# Altium schematic sheet MCU.SchDoc
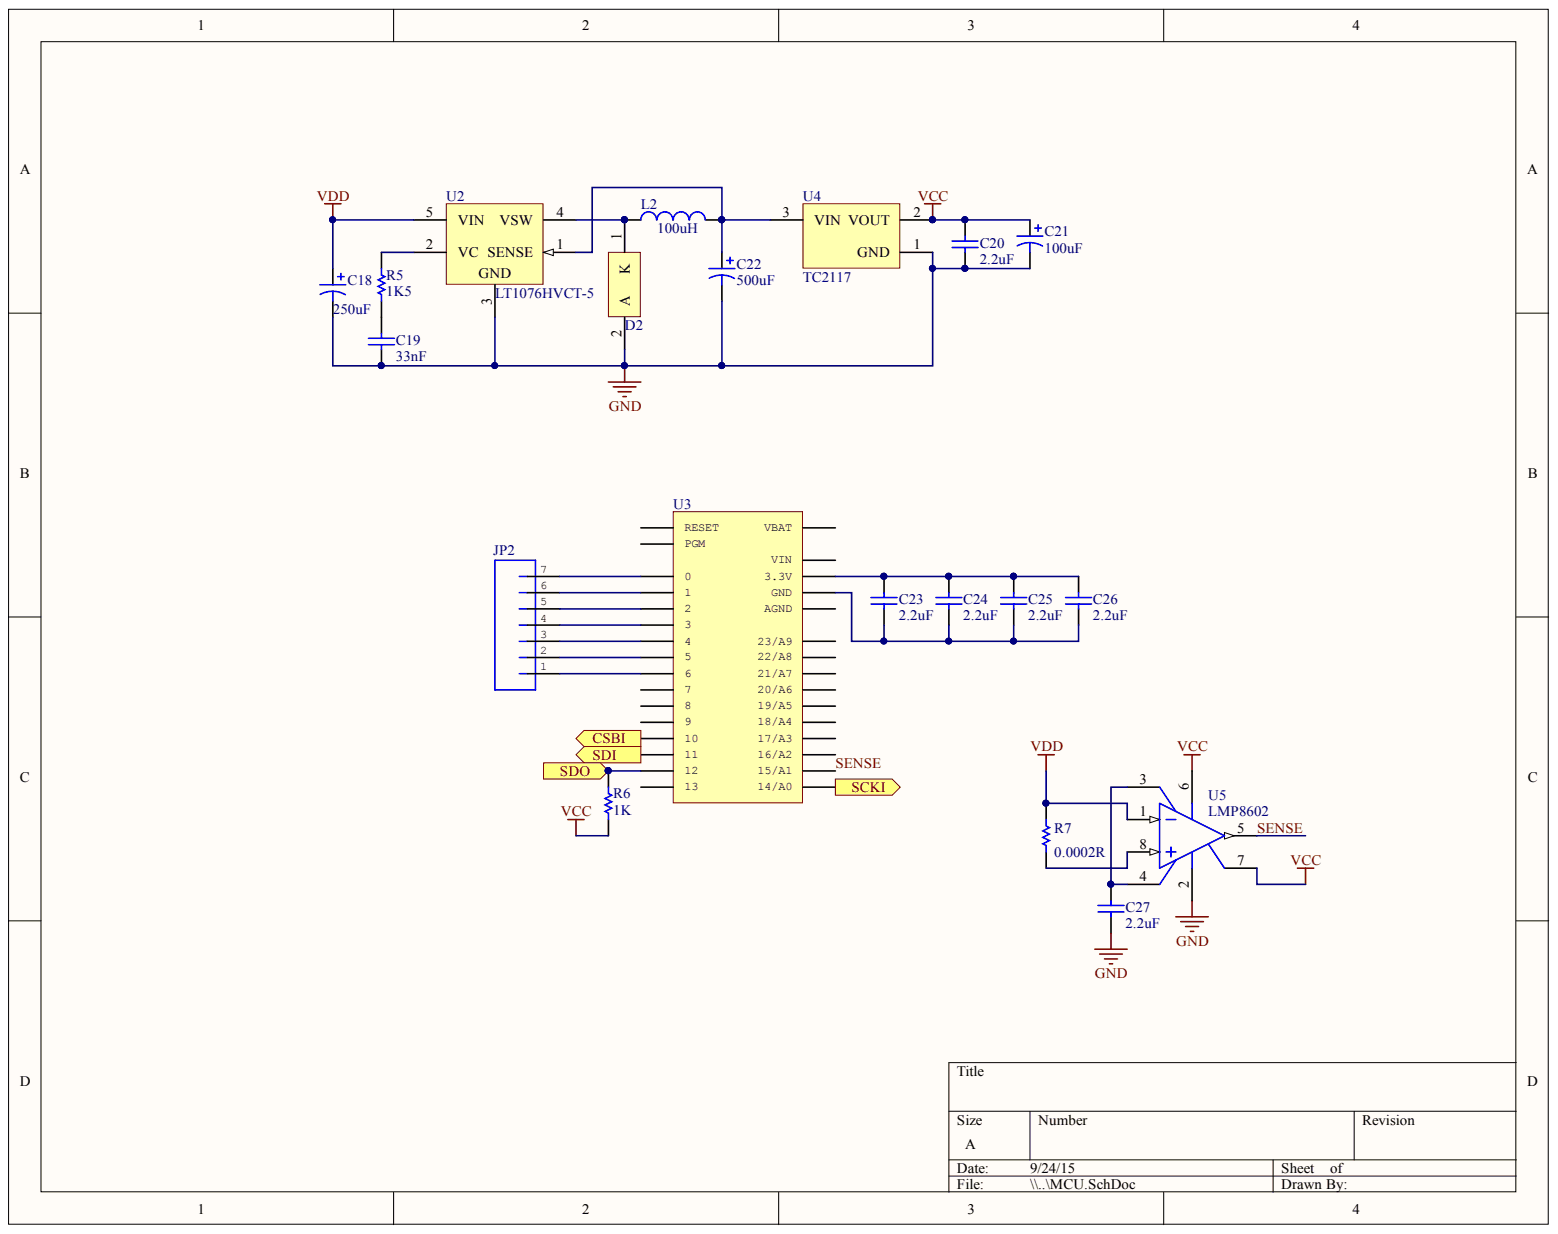
[diagram p0: MCU.SchDoc]

## Parts
C18  250uF  [250uF 100V]
C19  33nF  [CAPC0603(1608)100_M]
C20  2.2uF  [CAPC0603(1608)55_N]
C21  100uF  [AVX-CAPC7343_V]
C22  500uF  [500uF 25V]
C23  2.2uF  [CAPC0603(1608)55_N]
C24  2.2uF  [CAPC0603(1608)55_N]
C25  2.2uF  [CAPC0603(1608)55_N]
C26  2.2uF  [CAPC0603(1608)55_N]
C27  2.2uF  [CAPC0603(1608)55_N]
D2  (no value)  [ONSC-AXIAL_LEAD-2-267-05_V]
JP2  (no value)  [JST-7-PTH]
L2  value 100uH  [Inductor]
R5  1K5  [RESC0603(1608)_M]
R6  1K  [RESC0603(1608)_L]
R7  value 0.0002R  [WSLP5931]
U2  LT1076HVCT-5  [LT-T-5-S]
U3  (no value)  [TEENSY_3.0_BASIC]
U4  TC2117  [SOT-223-DB3_M]
U5  LMP8602  [M08A_L]

## Ports
CSBI, SCKI, SDI, SDO

## Nets
GND: C18.2 C19.1 C20.2 C21.2 C22.2 C27.1 D2.2 U2.3 U4.1 U5.2
VCC: C20.1 C21.1 R6.1 U4.2 U5.6 U5.7
VDD: C18.1 R7.2 U2.5(VIN) U5.1
CSBI: U3.10 ; port CSBI
SCKI: U3.14/A0 ; port SCKI
SDI: U3.11 ; port SDI
SDO: R6.2 U3.12 ; port SDO
SENSE: U3.15/A1 U5.5
NetC19_2: C19.2 R5.1
NetC22_1: C22.1 L2.2 U2.1(SENSE) U4.3
NetC23_1: C23.1 C24.1 C25.1 C26.1 U3.GND GND1(GND)
NetC23_2: C23.2 C24.2 C25.2 C26.2 U3.3.3V 3.3V1(3.3V)
NetC27_2: C27.2 U5.3 U5.4
NetD2_1: D2.1 L2.1 U2.4(VSW)
NetJP2_1: JP2.1 U3.6
NetJP2_2: JP2.2 U3.5
NetJP2_3: JP2.3 U3.4
NetJP2_4: JP2.4 U3.3
NetJP2_5: JP2.5 U3.2
NetJP2_6: JP2.6 U3.1
NetJP2_7: JP2.7 U3.0
NetR5_2: R5.2 U2.2(VC)
NetR7_1: R7.1 U5.8

Not connected: U3 7 8 9 13 16/A2 17/A3 18/A4 19/A5 20/A6 21/A7 22/A8 23/A9 AGND PGM RESET VBAT VIN

## Library data of the parts
C18 = CAP 250uF 100V: Polarized Capacitor (Axial); Component Kind: Standard; LatestRevisionDate: 17-Jul-2002; LatestRevisionNote: Re-released for DXP Platform.; Library Name: Components.SchLib; PackageDescription: Polarized Capacitor; 2 Leads; PackageReference: POLAR0.8; Published: 8-Jun-2000; Signal Integrity: Cap Pol; Simulation: CAP; Publisher: Altium Limited
C19 = CMP-1035-02452-1: CAP 33nF 6.3V Â±5% 0603 (1608 Metric) Thickness 1mm SMD; Case-EIA: 0603; Case-Metric: 1608; Component Kind: Standard; Library Name: Altium Content Vault; Max Thickness: 1 mm; Rated Voltage: 6.3 V; Technology: SMT; Tolerance: Â±5%; Value: 33nF
C20 C23-C27 = CMP-1035-02762-1: CAP 2.2uF 16V Â±10% 0603 (1608 Metric) Thickness 0.55mm SMD; Case-EIA: 0603; Case-Metric: 1608; Component Kind: Standard; Library Name: Altium Content Vault; Max Thickness: 0.55 mm; Rated Voltage: 16 V; Technology: SMT; Tolerance: Â±10%; Value: 2.2uF
C21 = CMP-0279-00002-1: Capacitor, Tantalum, 220uF 10% 10V MCCT-D (7343-31); Manufacturer: AVX; PartNumber: TAJD227K010R; Polarity: Polarized; Capacitance: 220uF; Component Kind: Standard; ComponentLink1Description: Manufacturer URL; ComponentLink1URL: http://www.avx.com/; ComponentLink2Description: Datasheet; ComponentLink2URL: http://www.avx.com/docs/Catalogs/taj.pdf; DatasheetVersion: Jul-13; Library Name: Altium Content Vault; Mounting Technology: Surface Mount; PackageDescription: 2-Pin SMT Capacitor, 7.3 x 4.3 mm Body; PackageReference: CAPC7343; Rated Voltage: 10V; Technology: Tantalum; Tolerance: 10%
C22 = CAP 500uF 25V: Polarized Capacitor (Axial); Component Kind: Standard; LatestRevisionDate: 17-Jul-2002; LatestRevisionNote: Re-released for DXP Platform.; Library Name: Components.SchLib; PackageDescription: Polarized Capacitor; 2 Leads; PackageReference: POLAR0.8; Published: 8-Jun-2000; Signal Integrity: Cap Pol; Simulation: CAP; Publisher: Altium Limited
D2 = CMP-1055-00021-1: Rectifier, 2-Pin Axial_Lead, Pb-Free, Bulk Bag; Manufacturer: On Semiconductor; PartNumber: MBR340G; Configuration: Single; IFSM Max (A): 80; Component Kind: Standard; ComponentLink1Description: Manufacturer URL; ComponentLink1URL: http://www.onsemi.com/; ComponentLink2Description: Datasheet; ComponentLink2URL: http://www.onsemi.cn/pub_link/Collateral/MBR340-D.PDF; ComponentLink3Description: Package Specification; ComponentLink3URL: http://www.onsemi.com/pub_link/Collateral/267-05.PDF; DatasheetVersion: Rev. 7; Library Name: Altium Content Vault; Mounting Technology: Through Hole; PackageDescription: 2-Pin, Axial Lead, Diameter 5.05 mm; PackageReference: AXIAL_LEAD-2-267-05; PackageVersion: Rev. G, 06/2000; Packing: Bulk Bag; RoHS: TRUE
JP2 = JST_7_RIGHT: Component Kind: Standard; Library Name: Components.SchLib
L2 = CTX100-1-52-R: Inductor; Code_IEC: 1005; Code_JEITA: 0402; Component Kind: Standard; LatestRevisionDate: 29-May-2009; LatestRevisionNote: IPC-7351 Footprint Added.; Library Name: Components.SchLib; PackageDescription: Chip Inductor; PackageReference: 0402-A; Published: 8-Jun-2000; Signal Integrity: Inductor; Simulation: INDUCTOR; Publisher: Altium Limited
R5 = CMP-1012-00078-1: 1K5 0.1W 5% 0603 (1608 Metric)  SMD; Case-EIA: 0603; Case-Metric: 1608; Value: 1500.00 Ohm; Component Kind: Standard; Library Name: Altium Content Vault; Power: 0.1 W; Technology: SMT; Tolerance: 5 %
R6 = CMP-1012-00074-1: 1K 0.1W 5% 0603 (1608 Metric)  SMD; Case-EIA: 0603; Case-Metric: 1608; Value: 1000.00 Ohm; Component Kind: Standard; Library Name: Altium Content Vault; Power: 0.1 W; Technology: SMT; Tolerance: 5 %
R7 = SHUNT: Resistor; Component Kind: Standard; LatestRevisionDate: 17-Jul-2002; LatestRevisionNote: Re-released for DXP Platform.; Library Name: Components.SchLib; PackageDescription: Axial Device, Thru-Hole; 2 Leads; 0.3 in Pin Spacing; PackageReference: AXIAL-0.3; Published: 8-Jun-2000; Signal Integrity: Res; Simulation: RESISTOR; Publisher: Altium Limited
U2 = CMP-0491-02013-1: Step-Down Switching Regulator, 5-pin FM (T-5), 0 to 70 degC; Manufacturer: Linear Technology; Number of Outputs: 1; Component Kind: Standard; ComponentLink1Description: Manufacturer URL; ComponentLink1URL: http://www.linear.com/; ComponentLink2Description: Datasheet; ComponentLink2URL: http://cds.linear.com/docs/en/datasheet/10765fc.pdf; ComponentLink3Description: Package Specification; ComponentLink3URL: http://cds.linear.com/docs/en/packaging/TO-220_5_05-08-1421.pdf; DatasheetVersion: Rev. C; Library Name: Altium Content Vault; Mounting Technology: Through Hole; PackageDescription: 5-Pin Plastic TO-220 (Standard), Body 10.224 x 8.89 mm, Pitch 1.7 mm; PackageReference: T-5; PackageVersion: Aug-10; PartNumber: LT1076HVCT-5; RoHS: FALSE
U3 = TEENSY_3.0_BASIC: Component Kind: Standard; Library Name: Components.SchLib
U4 = PM-LINEREG-TC2117-IL4: Component Kind: Standard; Library Name: Components.SchLib
U5 = CMP-0055-00659-1: 60V Common Mode, 50 x Gain, Bidirectional Precision Current Sensing Amplifier, 8 pin SOIC NARROW; Manufacturer: National Semiconductor; CompType: Amplifiers; PackageTopMarking: NSZXTT*LMP86*02QMA; PowerWise: N; Code_IPC: SOIC127P600-8; Code_JEDEC: MS-012-AA; Component Kind: Standard; DatasheetVersion: Mar-2010; Library Name: Altium Content Vault; PackageDescription: SOIC Narrow, 4.9 x 3.9 x 1.45mm, 8 Lead, 1.27mm Pitch; PackageReference: M08A; PackageVersion: revM, May-2009; PinCount: 8; RoHS: Y
